# Altium schematic sheet sheet03.SchDoc
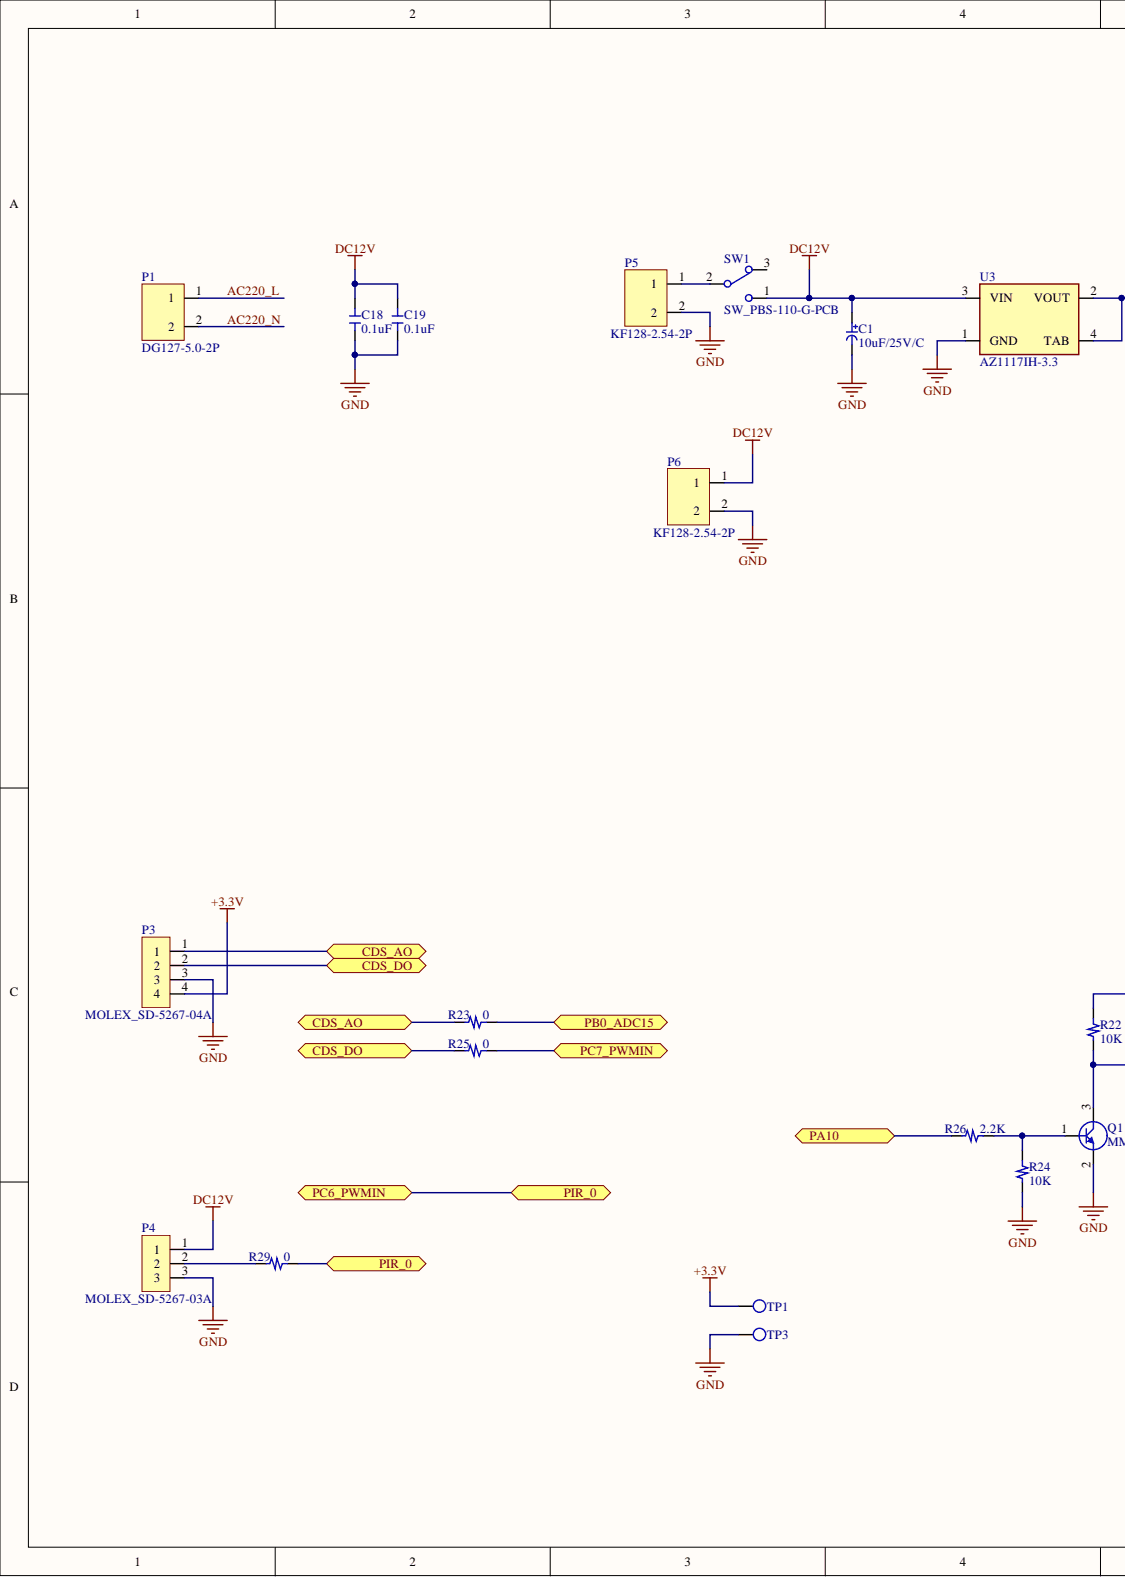
[diagram p0: sheet03.SchDoc, left]
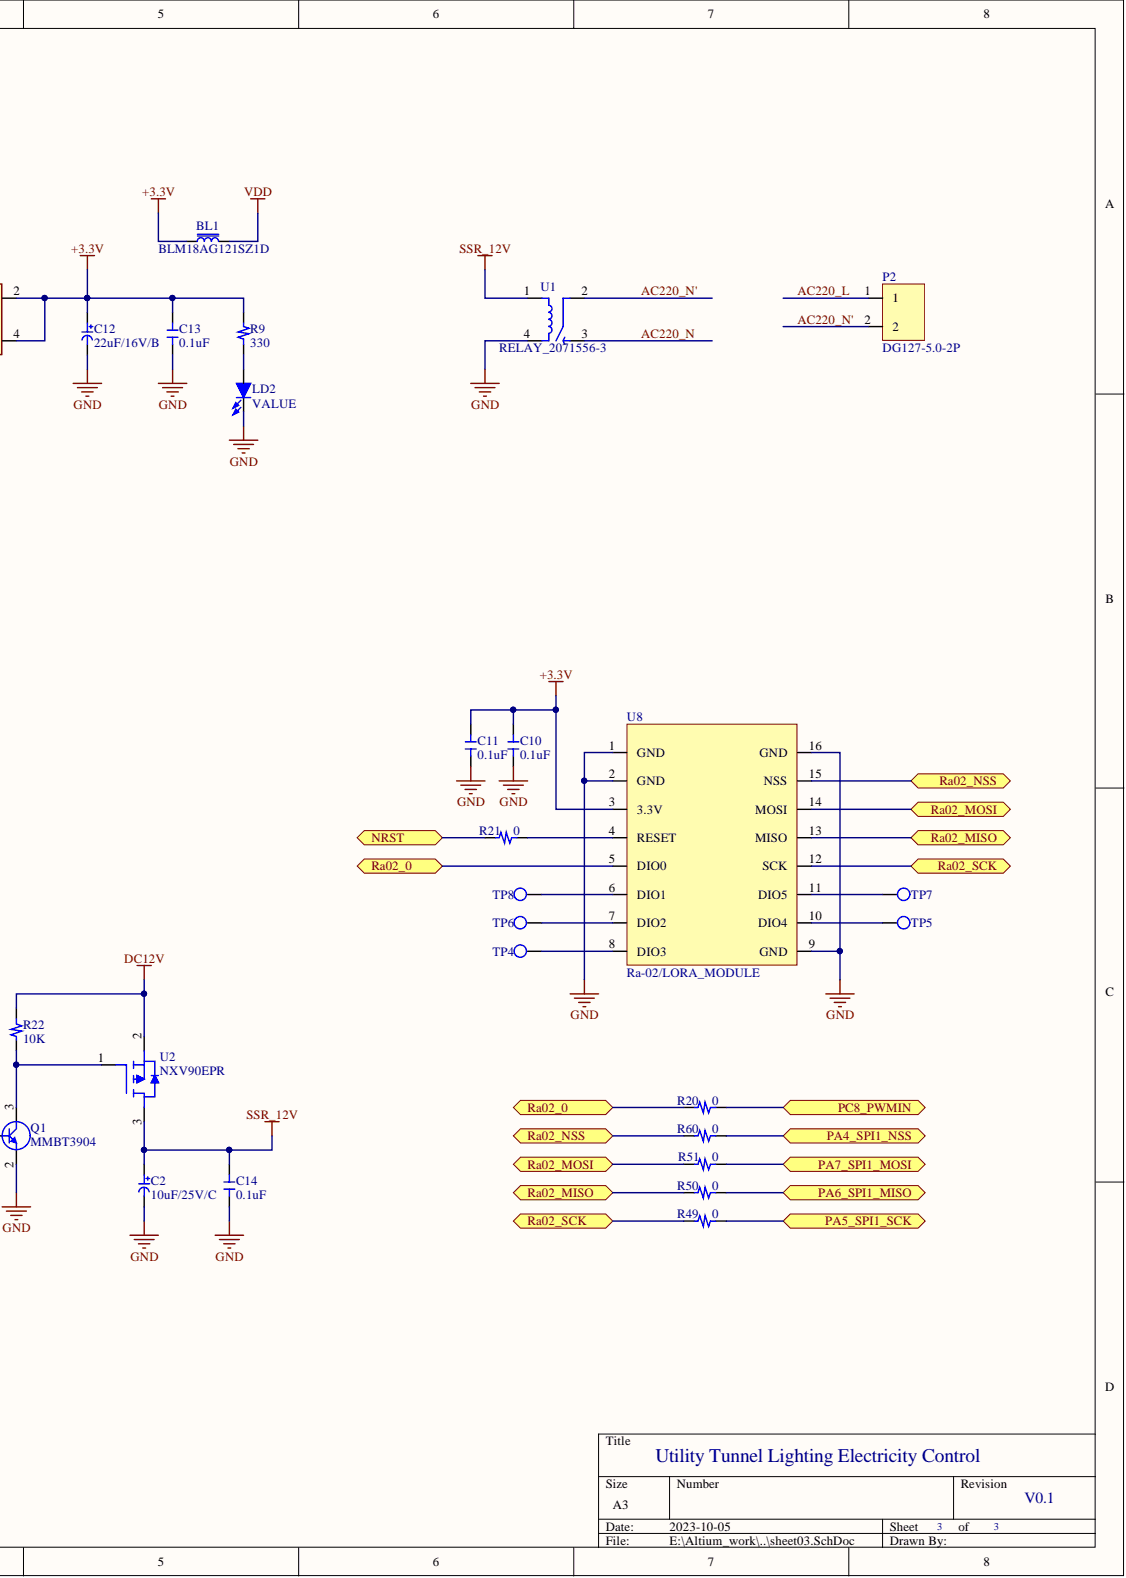
[diagram p1: sheet03.SchDoc, right]

## Parts
BL1  BLM18AG121SZ1D  [BLM18P]
C1  10uF/25V/C  [TC6032]
C2  10uF/25V/C  [TC6032]
C10  0.1uF  [CC1608]
C11  0.1uF  [CC1608]
C12  22uF/16V/B  [TC3528]
C13  0.1uF  [CC1608]
C14  0.1uF  [CC1608]
C18  0.1uF  [CC1608]
C19  0.1uF  [CC1608]
LD2  VALUE  [LED2012]
P1  DG127-5.0-2P  [DG127-5.0-2P]
P2  DG127-5.0-2P  [DG127-5.0-2P]
P3  MOLEX_SD-5267-04A  [MOLEX_SD-5267-04A]
P4  MOLEX_SD-5267-03A  [MOLEX_SD-5267-03A]
P5  KF128-2.54-2P  [KF128-2.54-2P]
P6  KF128-2.54-2P  [KF128-2.54-2P]
Q1  MMBT3904  [SOT23-3_2.9X1.3_0.95_H1.22]
R9  330  [RC1608]
R20  0  [RC1608]
R21  0  [RC1608]
R22  10K  [RC1608]
R23  0  [RC1608]
R24  10K  [RC1608]
R25  0  [RC1608]
R26  2.2K  [RC1608]
R29  0  [RC1608]
R49  0  [RC1608]
R50  0  [RC1608]
R51  0  [RC1608]
R60  0  [RC1608]
SW1  SW_PBS-110-G-PCB  [SW_PBS-110-G-PCB]
TP1  TP  [TP_0.9]
TP3  TP  [TP_0.9]
TP4  TP  [TP_0.9]
TP5  TP  [TP_0.9]
TP6  TP  [TP_0.9]
TP7  TP  [TP_0.9]
TP8  TP  [TP_0.9]
U1  RELAY_2071556-3  [RELAY_2071556-3]
U2  NXV90EPR  [SOT23-3_2.9X1.3_0.95_H1.1]
U3  AZ1117IH-3.3  [SOT223-4_6.5X3.5_2.3_H1.8]
U8  Ra-02/LORA_MODULE  [MODULE_Ra-02/LORA]

## Ports
CDS_AO, CDS_DO, NRST, PA4_SPI1_NSS, PA5_SPI1_SCK, PA6_SPI1_MISO, PA7_SPI1_MOSI, PA10, PB0_ADC15, PC6_PWMIN, PC7_PWMIN, PC8_PWMIN, PIR_0, Ra02_0, Ra02_MISO, Ra02_MOSI, Ra02_NSS, Ra02_SCK

## Nets
+3.3V: BL1.1 C10.2 C11.2 C12.1 C13.2 P3.4 R9.2 TP1.1 U3.2(VOUT) U3.4(TAB) U8.3(3.3V)
DC12V: C1.1 C18.2 C19.2 P4.1 P6.1 R22.1 SW1.1 U2.2 U3.3(VIN)
GND: C1.2 C2.2 C10.1 C11.1 C12.2 C13.1 C14.1 C18.1 C19.1 LD2.1 P3.3 P4.3 P5.2 P6.2 Q1.2 R24.2 TP3.1 U1.4 U3.1(GND) U8.1(GND) U8.2(GND) U8.9(GND) U8.16(GND)
SSR_12V: C2.1 C14.2 U1.1 U2.3
VDD: BL1.2
AC220_L: P1.1 P2.1
AC220_N: P1.2 U1.3
AC220_N': P2.2 U1.2
CDS_AO: P3.1 R23.2 ; port CDS_AO
CDS_DO: P3.2 R25.2 ; port CDS_DO
NRST: R21.2 ; port NRST
PA4_SPI1_NSS: R60.1 ; port PA4_SPI1_NSS
PA5_SPI1_SCK: R49.1 ; port PA5_SPI1_SCK
PA6_SPI1_MISO: R50.1 ; port PA6_SPI1_MISO
PA7_SPI1_MOSI: R51.1 ; port PA7_SPI1_MOSI
PA10: R26.2 ; port PA10
PB0_ADC15: R23.1 ; port PB0_ADC15
PC6_PWMIN: R29.1 ; port PC6_PWMIN, port PIR_0
PC7_PWMIN: R25.1 ; port PC7_PWMIN
PC8_PWMIN: R20.1 ; port PC8_PWMIN
Ra02_0: R20.2 U8.5(DIO0) ; port Ra02_0
Ra02_MISO: R50.2 U8.13(MISO) ; port Ra02_MISO
Ra02_MOSI: R51.2 U8.14(MOSI) ; port Ra02_MOSI
Ra02_NSS: R60.2 U8.15(NSS) ; port Ra02_NSS
Ra02_SCK: R49.2 U8.12(SCK) ; port Ra02_SCK
NetLD2_2: LD2.2 R9.1
NetP4_2: P4.2 R29.2
NetP5_1: P5.1 SW1.2
NetQ1_1: Q1.1 R24.1 R26.1
NetQ1_3: Q1.3 R22.2 U2.1
NetR21_1: R21.1 U8.4(RESET)
NetTP4_1: TP4.1 U8.8(DIO3)
NetTP5_1: TP5.1 U8.10(DIO4)
NetTP6_1: TP6.1 U8.7(DIO2)
NetTP7_1: TP7.1 U8.11(DIO5)
NetTP8_1: TP8.1 U8.6(DIO1)

Not connected: SW1 3

## Library data of the parts
BL1 = BEAD: Component Kind: Standard; Library Name: RLC.SchLib
C1 C2 C12 = TAN_CAP: Component Kind: Standard; Library Name: RLC.SchLib
C10 C11 C13 C14 C18 C19 = CAP: Component Kind: Standard; Library Name: RLC.SchLib
LD2 = LED: Component Kind: Standard; Library Name: RLC.SchLib
R9 R20-R26 R29 R49-R51 R60 = RES: Component Kind: Standard; Library Name: RLC.SchLib
U8 = MODULE_Ra-02/LORA: Component Kind: Standard; LatestRevisionDate: 17-Jul-2002; LatestRevisionNote: Re-released for DXP Platform.; PackageDescription: DIP Switch; 16 Leads; PackageReference: DIP_SW_8WAY_SMD; Published: 24-Mar-1999; Library Name: SW_XTAL_PWR_ETC.SchLib; Publisher: Altium Limited
P1 P2 = DG127-5.0-2P: Component Kind: Standard; Library Name: CONNECTOR.SchLib
P3 = MOLEX_SD-5267-04A: Component Kind: Standard; Library Name: CONNECTOR.SchLib
P4 = MOLEX_SD-5267-03A: Component Kind: Standard; Library Name: CONNECTOR.SchLib
P5 P6 = KF128-2.54-2P: Component Kind: Standard; Library Name: CONNECTOR.SchLib
Q1 = MMBT3904: Component Kind: Standard; Library Name: IC.SchLib
SW1 = SW_PBS-110-G-PCB: Component Kind: Standard; Library Name: SW_XTAL_PWR_ETC.SchLib
TP1 TP3-TP8 = TP: Component Kind: Standard; Library Name: CONNECTOR.SchLib
U1 = RELAY_2071556-3: Component Kind: Standard; Library Name: SW_XTAL_PWR_ETC.SchLib
U2 = NXV90EPR: Component Kind: Standard; Library Name: IC.SchLib
U3 = AZ1117IH-3.3: Component Kind: Standard; Library Name: IC.SchLib
